# Altium schematic sheet Control Panel.SchDoc("Control Panel")
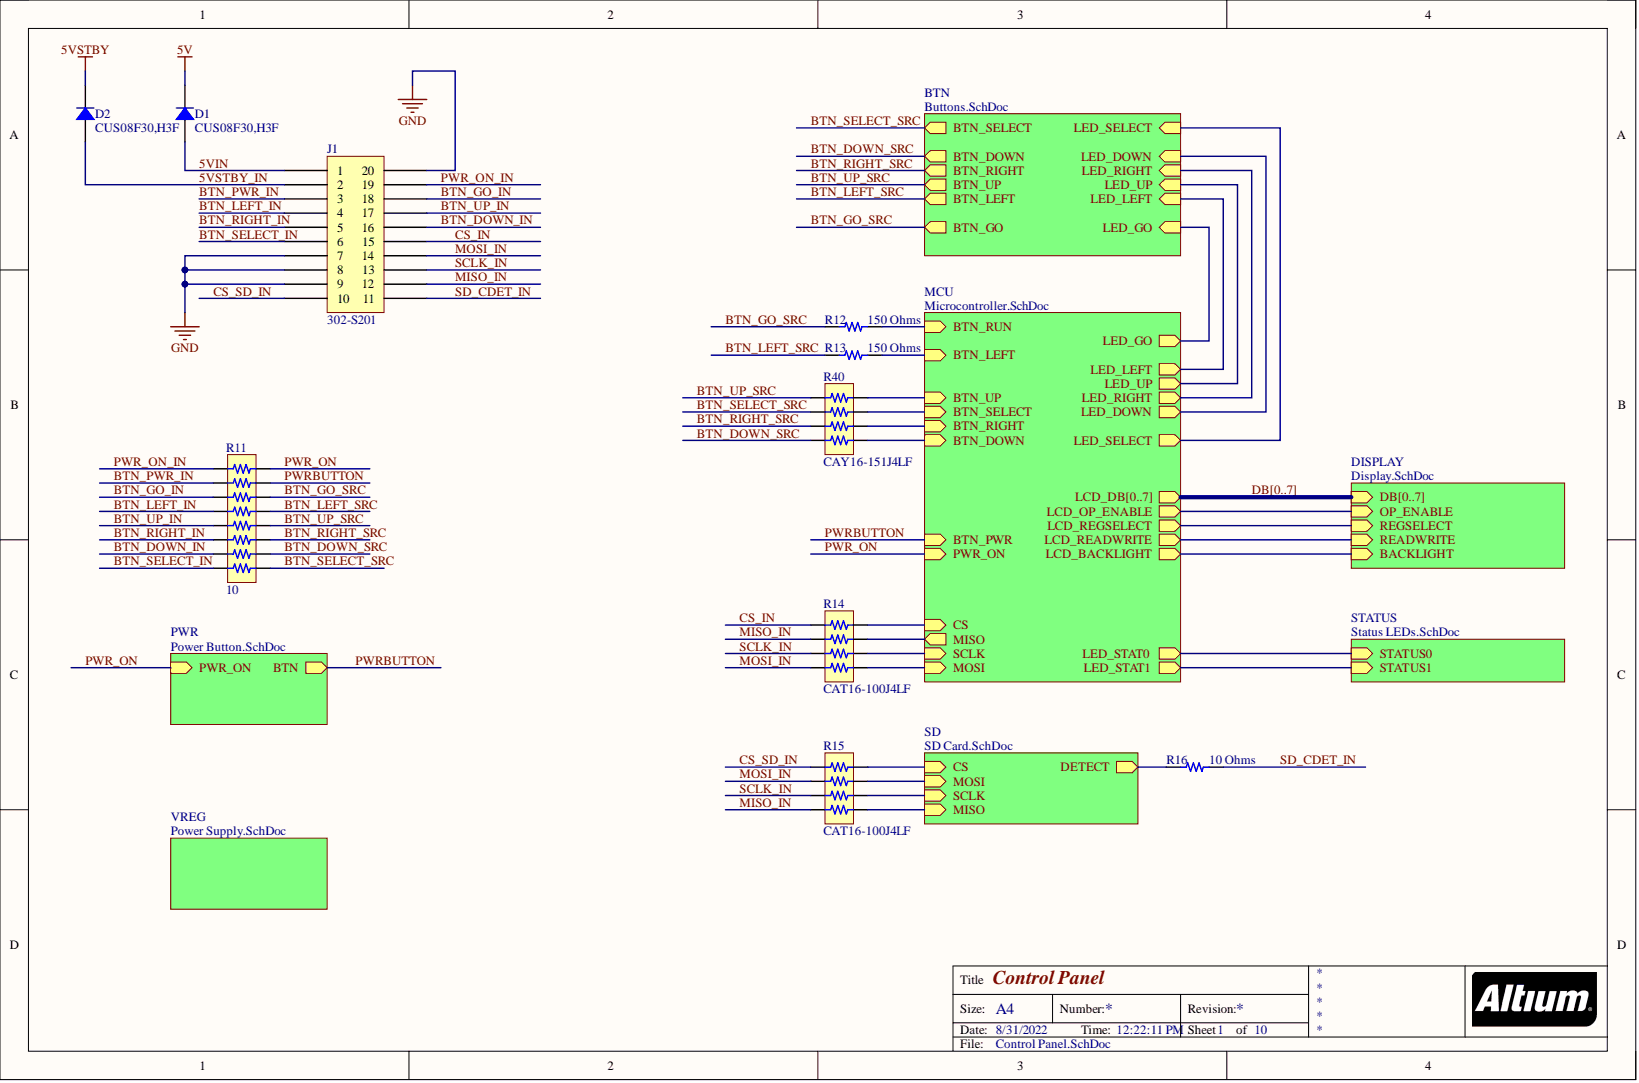
[diagram p0: Control Panel.SchDoc]

## Parts
D1  CUS08F30,H3F
D2  CUS08F30,H3F
J1  302-S201
R11  EXB-2HV100JV  (value not drawn)
R12  150 Ohms
R13  150 Ohms
R14  CAT16-100J4LF
R15  CAT16-100J4LF
R16  10 Ohms
R40  CAY16-151J4LF

## Nets
5V: D1.2
5VSTBY: D2.2
GND: J1.7 J1.8 J1.9 J1.20
5VIN: D1.1 J1.1
5VSTBY_IN: D2.1 J1.2
BTN_DOWN_IN: J1.16 R11.7
BTN_DOWN_SRC: R11.10 R40.4
BTN_GO_IN: J1.18 R11.3
BTN_GO_SRC: R11.14 R12.1
BTN_LEFT_IN: J1.4 R11.4
BTN_LEFT_SRC: R11.13 R13.1
BTN_PWR_IN: J1.3 R11.2
BTN_RIGHT_IN: J1.5 R11.6
BTN_RIGHT_SRC: R11.11 R40.3
BTN_SELECT_IN: J1.6 R11.8
BTN_SELECT_SRC: R11.9 R40.2
BTN_UP_IN: J1.17 R11.5
BTN_UP_SRC: R11.12 R40.1
CS_IN: J1.15 R14.1
CS_SD_IN: J1.10 R15.1
MISO_IN: J1.12 R14.2 R15.4
MOSI_IN: J1.14 R14.4 R15.2
PWR_ON: R11.16
PWR_ON_IN: J1.19 R11.1
PWRBUTTON: R11.15
SCLK_IN: J1.13 R14.3 R15.3
SD_CDET_IN: J1.11 R16.1
NetR12_2: R12.2
NetR13_2: R13.2
NetR14_5: R14.5
NetR14_6: R14.6
NetR14_7: R14.7
NetR14_8: R14.8
NetR15_5: R15.5
NetR15_6: R15.6
NetR15_7: R15.7
NetR15_8: R15.8
NetR16_2: R16.2
NetR40_5: R40.5
NetR40_6: R40.6
NetR40_7: R40.7
NetR40_8: R40.8
BACKLIGHT:
DB0:  [also labelled DB]
DB1:  [also labelled DB]
DB2:  [also labelled DB]
DB3:  [also labelled DB]
DB4:  [also labelled DB]
DB5:  [also labelled DB]
DB6:  [also labelled DB]
DB7:  [also labelled DB]
LCD_OP_ENABLE:
LCD_READWRITE:
LCD_REGSELECT:
LED_DOWN:
LED_GO:
LED_LEFT:
LED_RIGHT:
LED_SELECT:
LED_STAT0:
LED_STAT1:
LED_UP:

## Library data of the parts
D1 D2 = CUS08F30,H3F: DIODE SCHOTTKY 30V 800MA USC; Manufacturer: Toshiba Semiconductor and Storage; Capacitance @ Vr, F: 170pF @ 0V, 1MHz; Current - Reverse Leakage @ Vr: 50 µA @ 30 V; Database Table Name: Diodes - Rectifiers - Single; Speed: Fast Recovery =< 500ns, > 200mA (Io); Voltage - Forward (Vf) (Max) @ If: 220 mV @ 10 mA; Component Kind: Standard; ComponentLink1Description: Datasheet; ComponentLink1URL: https://toshiba.semicon-storage.com/info/docget.jsp?did=9019&prodName=CUS08F30; ComponentLink2Description: DigiKey Link; ComponentLink2URL: https://www.digikey.com/en/products/detail/toshiba-semiconductor-and-storage/CUS08F30-H3F/5114298; Current - Average Rectified (Io): 800mA; Diode Type: Schottky; LastUpdated: 11/08/2022 09:50:39; Lifecycle Status: Active; Minimum Order: 1; Mounting Type: Surface Mount; Operating Temperature - Junction: 125°C (Max); Package / Case: SC-76, SOD-323; Packaging: TapeAndReel; Part Number: CUS08F30,H3F; Part Status: Active; PartId: 3013; Price: 0.04; Series: -; Supplier 1: DigiKey; Supplier Device Package: USC; Supplier Part Number 1: CUS08F30H3FCT-ND; Voltage - DC Reverse (Vr) (Max): 30 V; Library Name: altiumlibrary.com.DbLib
J1 = 302-S201: CONN HEADER VERT 20POS 2.54MM; Manufacturer: On Shore Technology Inc.; Contact Length - Post: 0.122" (3.10mm); Current Rating (Amps): 3A; Database Table Name: Connectors - Rectangular Headers Male Pins; Material Flammability Rating: UL94 V-0; Number of Positions: 20; Number of Positions Loaded: All; Pitch - Mating: 0.100" (2.54mm); Shrouding: Shrouded - 4 Wall; Style: Board to Cable/Wire; Component Kind: Standard; ComponentLink1Description: Datasheet; ComponentLink1URL: http://www.on-shore.com/wp-content/uploads/2018/04/302-SXX1.pdf; ComponentLink2Description: DigiKey Link; ComponentLink2URL: https://www.digikey.com/en/products/detail/on-shore-technology-inc/302-S201/2794235; Connector Type: Header; Contact Finish - Mating: Gold; Contact Finish - Post: Gold; Contact Finish Thickness - Mating: Flash; Contact Material: Brass; Contact Shape: Square; Contact Type: Male Pin; Fastening Type: Push-Pull; Features: Keying Slot; Insulation Color: Black; Insulation Height: 0.358" (9.10mm); Insulation Material: Polyester, Glass Filled; LastUpdated: 14/08/2022 17:03:46; Lifecycle Status: Active; Minimum Order: 1; Mounting Type: Through Hole; Number of Rows: 2; Operating Temperature: -40°C ~ 105°C; Packaging: Tray; Part Number: 302-S201; Part Status: Active; PartId: 155; Price: 0.19; Row Spacing - Mating: 0.100" (2.54mm); Series: 302; Supplier 1: DigiKey; Supplier Part Number 1: ED10524-ND; Termination: Solder; Library Name: altiumlibrary.com.DbLib
R11 = EXB-2HV100JV: RES ARRAY 8 RES 10 OHM 1506; Manufacturer: Panasonic Electronic Components; Circuit Type: Isolated; Database Table Name: Resistors -  Arrays and Networks; Number of Resistors: 8; Power Per Element: 62.5mW; Resistance (Ohms): 10; Temperature Coefficient: ±200ppm/°C; Tolerance: ±5%; Applications: Automotive AEC-Q200; Component Kind: Standard; ComponentLink1Description: Datasheet; ComponentLink1URL: https://industrial.panasonic.com/cdbs/www-data/pdf/AOC0000/AOC0000C14.pdf; ComponentLink2Description: DigiKey Link; ComponentLink2URL: https://www.digikey.com/en/products/detail/panasonic-electronic-components/EXB-2HV100JV/285295; Height - Seated (Max): 0.022" (0.55mm); LastUpdated: 11/08/2022 09:42:42; Lifecycle Status: Active; Minimum Order: 1; Mounting Type: Surface Mount; Number of Pins: 16; Operating Temperature: -55°C ~ 125°C; Package / Case: 1506, Convex, Long Side Terminals; Packaging: TapeAndReel; Part Number: EXB-2HV100JV; Part Status: Active; PartId: 659; Price: 0.03; Series: EXB; Size / Dimension: 0.150" L x 0.063" W (3.80mm x 1.60mm); Supplier 1: DigiKey; Supplier Device Package: 1506; Supplier Part Number 1: Y1100CT-ND; Library Name: altiumlibrary.com.DbLib
R12 R13 = RC0603FR-07150RL: RES 150 OHM 1% 1/10W 0603; Manufacturer: Yageo; Database Table Name: Resistors - Surface Mount - 0603; Power (Watts): 0.1W, 1/10W; Temperature Coefficient: ±100ppm/°C; Component Kind: Standard; ComponentLink1Description: Datasheet; ComponentLink1URL: https://www.yageo.com/upload/media/product/productsearch/datasheet/rchip/PYu-RC_Group_51_RoHS_L_10.pdf; ComponentLink2Description: DigiKey Link; ComponentLink2URL: https://www.digikey.com/en/products/detail/yageo/RC0603FR-07150RL/726958; Composition: Thick Film; Device Package: 0603 (1608 Metric); Features: Moisture Resistant; Height - Seated (Max): 0.022" (0.55mm); LastUpdated: 11/08/2022 09:13:22; Lifecycle Status: Active; Minimum Order: 1; Number of Terminations: 2; Operating Temperature: -55°C ~ 155°C; Package / Case: 0603 (1608 Metric); Packaging: CutTape; Part Number: RC0603FR-07150RL; Part Status: Active; PartId: 18947; Price: 0.01; Resistance: 150 Ohms; Series: RC_L; Size / Dimension: 0.063" L x 0.031" W (1.60mm x 0.80mm); Supplier 1: DigiKey; Supplier Device Package: 0603; Supplier Part Number 1: 311-150HRCT-ND; Tolerance: ±1%; Value: 150 Ohms; Library Name: altiumlibrary.com.DbLib
R14 R15 = CAT16-100J4LF: RES ARRAY 4 RES 10 OHM 1206; Manufacturer: Bourns Inc.; Circuit Type: Isolated; Database Table Name: Resistors -  Arrays and Networks; Number of Resistors: 4; Power Per Element: 62.5mW; Resistance (Ohms): 10; Temperature Coefficient: ±200ppm/°C; Tolerance: ±5%; Component Kind: Standard; ComponentLink1Description: Datasheet; ComponentLink1URL: https://www.bourns.com/docs/Product-Datasheets/CATCAY.pdf; ComponentLink2Description: DigiKey Link; ComponentLink2URL: http://digikey.com/product-detail/en/bourns-inc/CAT16-100J4LF/CAT16-100J4LFCT-ND/3592901; Height - Seated (Max): 0.024" (0.60mm); LastUpdated: 02/09/2020 01:23:31; Lifecycle Status: Active; Minimum Order: 1; Mounting Type: Surface Mount; Number of Pins: 8; Operating Temperature: -55°C ~ 125°C; Package / Case: 1206 (3216 Metric), Concave, Long Side Terminals; Packaging: TapeAndReel; Part Number: CAT16-100J4LF; Part Status: Active; PartId: 910; Price: 0.01; Series: CAT16; Size / Dimension: 0.126" L x 0.061" W (3.20mm x 1.55mm); Supplier 1: DigiKey; Supplier Device Package: 1206; Supplier Part Number 1: CAT16-100J4LFCT-ND; Library Name: altiumlibrary.com.DbLib
R16 = RC0603FR-0710RL: RES 10 OHM 1% 1/10W 0603; Manufacturer: Yageo; Database Table Name: Resistors - Surface Mount - 0603; Power (Watts): 0.1W, 1/10W; Temperature Coefficient: ±200ppm/°C; Component Kind: Standard; ComponentLink1Description: Datasheet; ComponentLink1URL: https://www.yageo.com/upload/media/product/productsearch/datasheet/rchip/PYu-RC_Group_51_RoHS_L_10.pdf; ComponentLink2Description: DigiKey Link; ComponentLink2URL: https://www.digikey.com/en/products/detail/yageo/RC0603FR-0710RL/726879; Composition: Thick Film; Device Package: 0603 (1608 Metric); Features: Moisture Resistant; Height - Seated (Max): 0.022" (0.55mm); LastUpdated: 11/08/2022 09:13:14; Lifecycle Status: Active; Minimum Order: 1; Number of Terminations: 2; Operating Temperature: -55°C ~ 155°C; Package / Case: 0603 (1608 Metric); Packaging: TapeAndReel; Part Number: RC0603FR-0710RL; Part Status: Active; PartId: 22607; Price: 0.01; Resistance: 10 Ohms; Series: RC_L; Size / Dimension: 0.063" L x 0.031" W (1.60mm x 0.80mm); Supplier 1: DigiKey; Supplier Device Package: 0603; Supplier Part Number 1: 311-10.0HRCT-ND; Tolerance: ±1%; Value: 10 Ohms; Library Name: altiumlibrary.com.DbLib
R40 = CAY16-151J4LF: RES ARRAY 4 RES 150 OHM 1206; Manufacturer: Bourns Inc.; Circuit Type: Isolated; Database Table Name: Resistors -  Arrays and Networks; Number of Resistors: 4; Power Per Element: 62.5mW; Resistance (Ohms): 150; Temperature Coefficient: ±200ppm/°C; Tolerance: ±5%; Component Kind: Standard; ComponentLink1Description: Datasheet; ComponentLink1URL: https://www.bourns.com/docs/Product-Datasheets/CATCAY.pdf; ComponentLink2Description: DigiKey Link; ComponentLink2URL: http://digikey.com/product-detail/en/bourns-inc/CAY16-151J4LF/CAY16-151J4LFCT-ND/3437834; Height - Seated (Max): 0.024" (0.60mm); LastUpdated: 02/09/2020 01:23:25; Lifecycle Status: Active; Minimum Order: 1; Mounting Type: Surface Mount; Number of Pins: 8; Operating Temperature: -55°C ~ 125°C; Package / Case: 1206 (3216 Metric), Convex, Long Side Terminals; Packaging: TapeAndReel; Part Number: CAY16-151J4LF; Part Status: Active; PartId: 54; Price: 0.01; Series: CAY16; Size / Dimension: 0.126" L x 0.063" W (3.20mm x 1.60mm); Supplier 1: DigiKey; Supplier Device Package: 1206; Supplier Part Number 1: CAY16-151J4LFCT-ND; Library Name: altiumlibrary.com.DbLib
